# Altium schematic sheet 4-20mA输出.SchDoc(4-20mA输出)
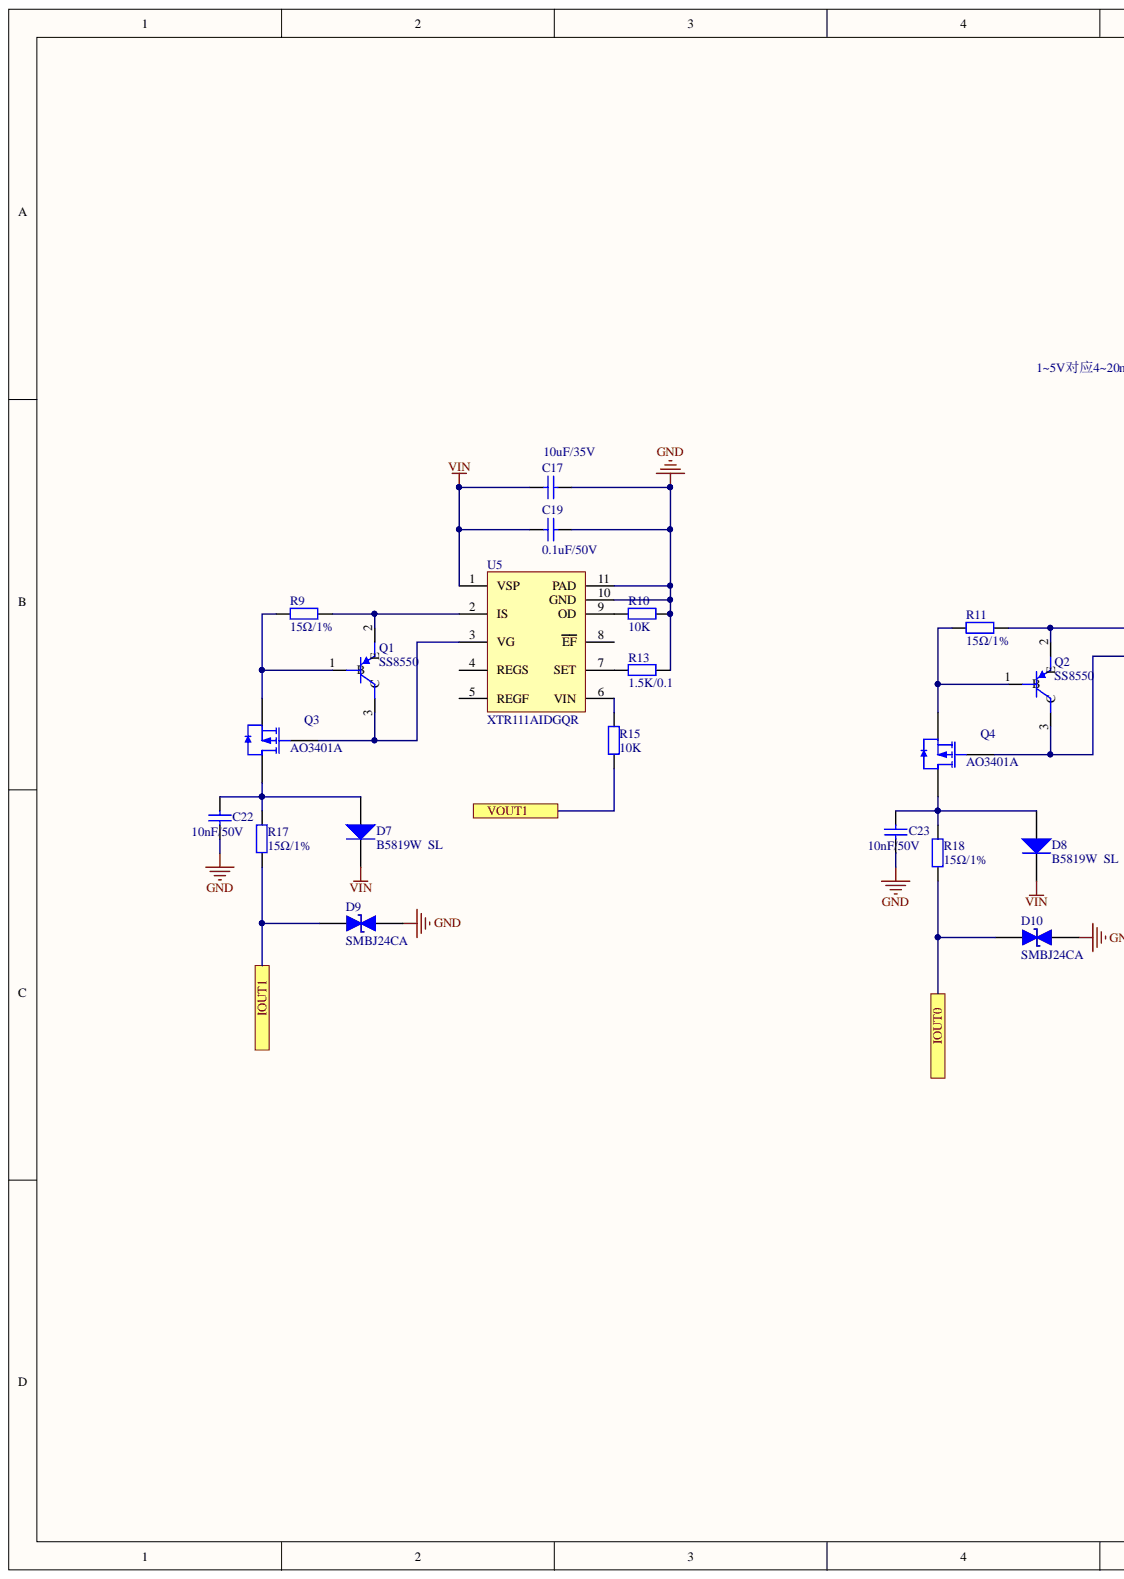
[diagram p0: 4-20mA输出.SchDoc, left]
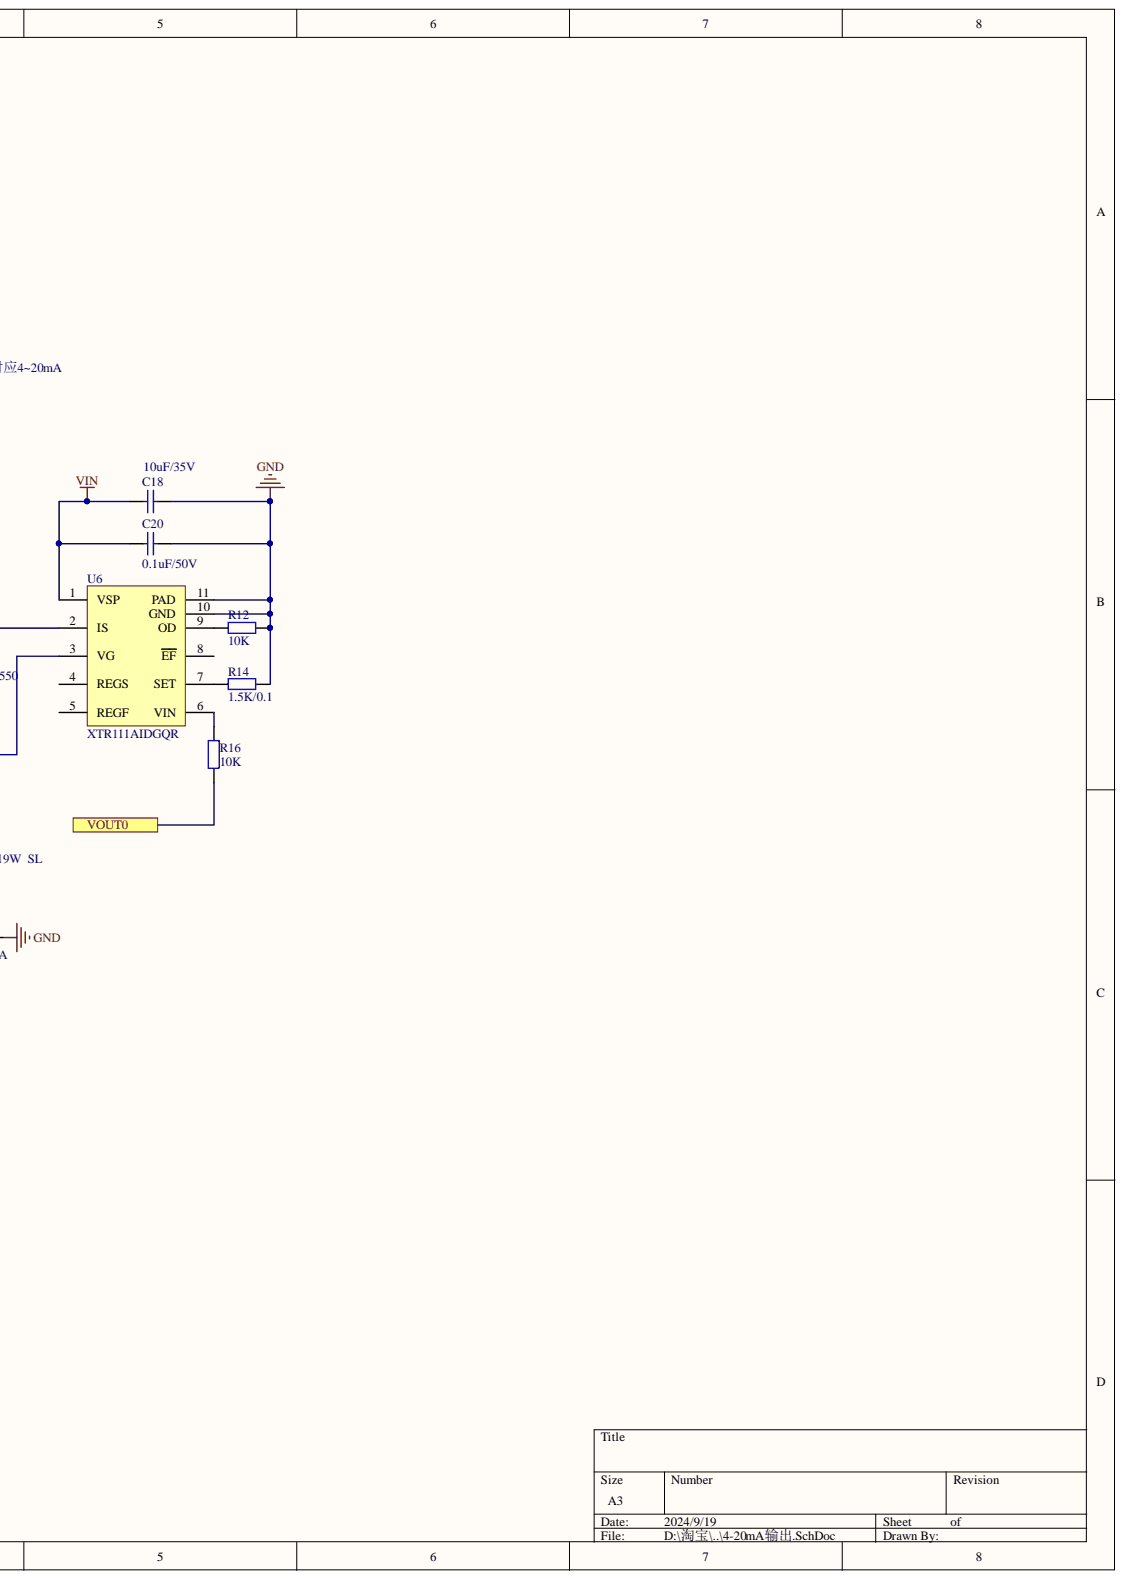
[diagram p1: 4-20mA输出.SchDoc, right]

## Parts
C17  10uF/35V  [0805_C]
C18  10uF/35V  [0805_C]
C19  0.1uF/50V  [0603_C]
C20  0.1uF/50V  [0603_C]
C22  10nF/50V  [0603_C]
C23  10nF/50V  [0603_C]
D7  B5819W  SL  [SOD-123]
D8  B5819W  SL  [SOD-123]
D9  SMBJ24CA  [SMB(DO-214AA)_S1]
D10  SMBJ24CA  [SMB(DO-214AA)_S1]
Q1  SS8550  [SOT-23(SOT-23-3)]
Q2  SS8550  [SOT-23(SOT-23-3)]
Q3  AO3401A  [SOT-23(SOT-23-3)]
Q4  AO3401A  [SOT-23(SOT-23-3)]
R9  15Ω/1%  [0603_R]
R10  10K  [0603_R]
R11  15Ω/1%  [0603_R]
R12  10K  [0603_R]
R13  1.5K/0.1  [0805_R]
R14  1.5K/0.1  [0805_R]
R15  10K  [0603_R]
R16  10K  [0603_R]
R17  15Ω/1%  [0603_R]
R18  15Ω/1%  [0603_R]
U5  XTR111AIDGQR  [MSOP-10 - EP]
U6  XTR111AIDGQR  [MSOP-10 - EP]

## Ports
IOUT0, IOUT1, VOUT0, VOUT1

## Nets
GND: C17.1 C18.1 C19.1 C20.1 C22.1 C23.1 D9.1 D10.1 R10.2 R12.2 R13.2 R14.2 U5.10(GND) U5.11(PAD) U6.10(GND) U6.11(PAD)
VIN: C17.2 C18.2 C19.2 C20.2 D7.2 D8.2 U5.1(VSP) U6.1(VSP)
IOUT0: D10.2 R18.2 ; port IOUT0
IOUT1: D9.2 R17.2 ; port IOUT1
VOUT0: R16.2 ; port VOUT0
VOUT1: R15.2 ; port VOUT1
NetC22_2: C22.2 D7.1 Q3.3 R17.1
NetC23_2: C23.2 D8.1 Q4.3 R18.1
NetQ1_1: Q1.1 Q3.2 R9.2
NetQ1_2: Q1.2 R9.1 U5.2(IS)
NetQ1_3: Q1.3 Q3.1 U5.3(VG)
NetQ2_1: Q2.1 Q4.2 R11.2
NetQ2_2: Q2.2 R11.1 U6.2(IS)
NetQ2_3: Q2.3 Q4.1 U6.3(VG)
NetR10_1: R10.1 U5.9(OD)
NetR12_1: R12.1 U6.9(OD)
NetR13_1: R13.1 U5.7(SET)
NetR14_1: R14.1 U6.7(SET)
NetR15_1: R15.1 U5.6(VIN)
NetR16_1: R16.1 U6.6(VIN)

Not connected: U5 4(REGS) 5(REGF) 8(EF); U6 4(REGS) 5(REGF) 8(EF)

## Library data of the parts
C17-C20 C22 C23 = C(0805): 贴片电容; Component Kind: Standard; Library Name: SAM.SchLib
D7 D8 = SOD-123 B5819W  SL: 肖特基二极管; SuppliersPartNumber: C8598; Supplier: LC; Package: SOD-123; Library Name: Diode.SchLib; ComponentLink1URL: http://www.szlcsc.com/product/details_9093.html; ComponentLink1Description: 供应商链接; Component Kind: Standard
D9 D10 = SMF33CA: TVS二极管; Library Name: My_library.SchLib; Component Kind: Standard
Q1 Q2 = PNP: Library Name: My_library.SchLib; Component Kind: Standard
Q3 Q4 = AO3401A P道沟 X13A15 SOT-23: MOS(场效应管); Value: AO3401; SuppliersPartNumber: C15127; Supplier: LC; Package: SOT-23; Library Name: MOS.SchLib; ComponentLink1URL: http://www.szlcsc.com/product/details_15801.html; ComponentLink1Description: 供应商链接; Component Kind: Standard
R9-R18 = Res2: 贴片电阻; Simulation: RESISTOR; Signal Integrity: Res; Published: 8-Jun-2000; PackageReference: AXIAL-0.4; PackageDescription: Axial Device, Thru-Hole; 2 Leads; 0.4 in Pin Spacing; Library Name: Miscellaneous Devices.IntLib; LatestRevisionNote: Re-released for DXP Platform.; LatestRevisionDate: 17-Jul-2002; Component Kind: Standard; Publisher: Altium Limited
U5 U6 = XTR111AIDGQR: Library Name: My_library.SchLib; Component Kind: Standard
